# Altium schematic sheet Vapeix_mini_Shield.SchDoc
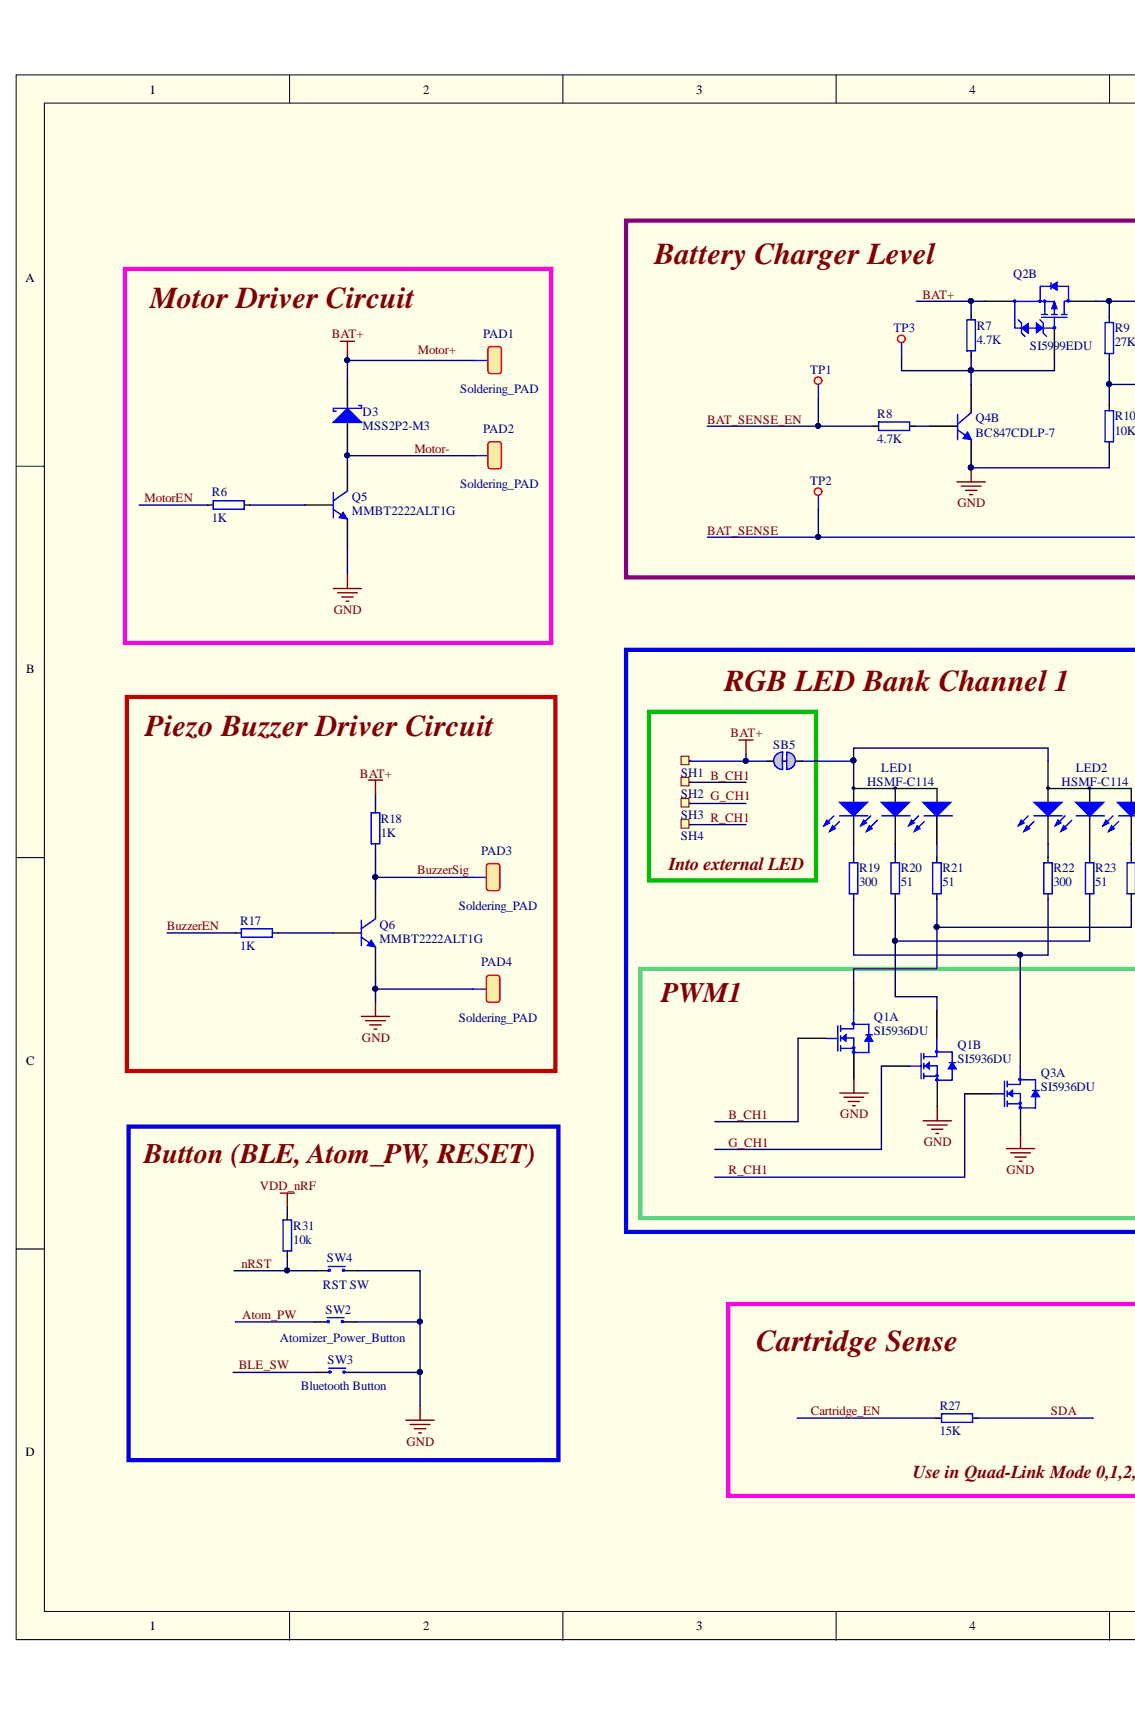
[diagram p0: Vapeix_mini_Shield.SchDoc, left]
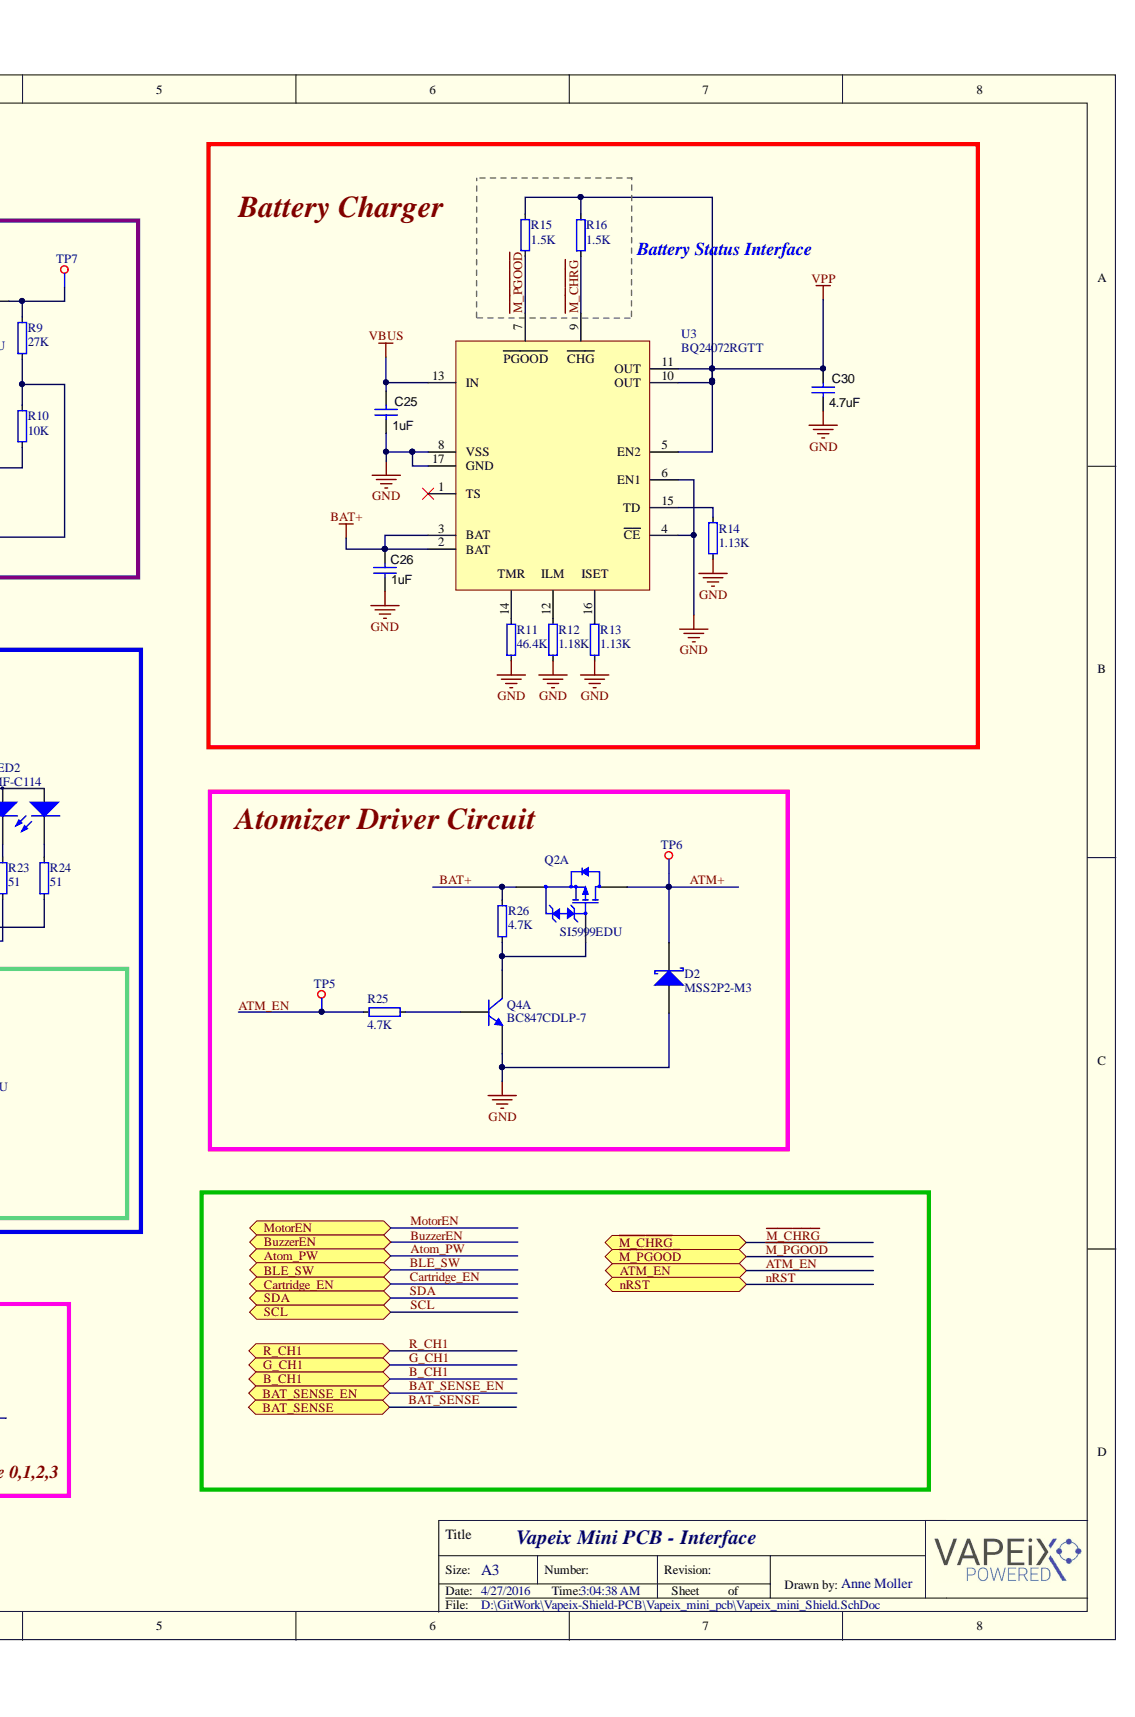
[diagram p1: Vapeix_mini_Shield.SchDoc, right]

## Parts
C25  ValueDisplayed: 1uF  [CAPC1608X06L]
C26  ValueDisplayed: 1uF  [CAPC1608X06L]
C30  ValueDisplayed: 4.7uF  [CAPC1608X06L]
D2  MSS2P2-M3  [MSS2P2-M3]
D3  MSS2P2-M3  [MSS2P2-M3]
LED1  HSMF-C114  [HSMF-C114]
LED2  HSMF-C114  [HSMF-C114]
PAD1  Soldering_PAD  [Soldering_PAD]
PAD2  Soldering_PAD  [Soldering_PAD]
PAD3  Soldering_PAD  [Soldering_PAD]
PAD4  Soldering_PAD  [Soldering_PAD]
Q1  SI5936DU  [SI5936DU]  (parts A,B)
Q2  SI5999EDU  [SI5999EDU]  (parts A,B)
Q3  SI5936DU  [SI5936DU]  (part A)
Q4  BC847CDLP-7  [BC847CDLP-7]  (parts A,B)
Q5  MMBT2222ALT1G  [MMBT2222ALT1G]
Q6  MMBT2222ALT1G  [MMBT2222ALT1G]
R6  1K  [RESC1005X04L]
R7  4.7K  [RESC1005X04L]
R8  4.7K  [RESC1005X04L]
R9  27K  [RESC1005X04L]
R10  10K  [RESC1005X04L]
R11  46.4K  [RESC1005X04L]
R12  1.18K  [RESC1005X04L]
R13  1.13K  [RESC1005X04L]
R14  1.13K  [RESC1005X04L]
R15  1.5K  [RESC1005X04L]
R16  1.5K  [RESC1005X04L]
R17  1K  [RESC1005X04L]
R18  1K  [RESC1005X04L]
R19  300  [RESC1005X04L]
R20  51  [RESC1005X04L]
R21  51  [RESC1005X04L]
R22  300  [RESC1005X04L]
R23  51  [RESC1005X04L]
R24  51  [RESC1005X04L]
R25  4.7K  [RESC1005X04L]
R26  4.7K  [RESC1005X04L]
R27  15K  [RESC1005X04L]
R31  10k  [RESC1005X04L]
SB5  Solderbridge  [Solderbridge_Shorted]  (value not drawn)
SH1  TP  [TESTPAD-TH]  (value not drawn)  (no BOM)
SH2  TP  [TESTPAD-TH]  (value not drawn)  (no BOM)
SH3  TP  [TESTPAD-TH]  (value not drawn)  (no BOM)
SH4  TP  [TESTPAD-TH]  (value not drawn)  (no BOM)
SW2  Atomizer_Power_Button  [ALPS_SKHUxxx010_WO_GND]
SW3  Bluetooth Button  [ALPS_SKHUxxx010_WO_GND]
SW4  RST SW  [ALPS_SKHUxxx010_WO_GND]
TP1  TP  [TP]
TP2  TP  [TP]
TP3  TP  [TP]
TP5  TP  [TP]
TP6  TP  [TP]
TP7  TP  [TP]
U3  BQ24072RGTT  [BQ24072RGTT]

## Ports
ATM_EN, ATOM_PW, B_CH1, BAT_SENSE, BAT_SENSE_EN, BLE_SW, BUZZEREN, CARTRIDGE_EN, G_CH1, MOTOREN, NRST, R_CH1, SCL, SDA, ~{M_CHRG}, ~{M_PGOOD}

## Nets
GND: C25.2 C26.2 C30.2 D2.1 PAD4.1 Q1.1 Q1.3 Q3.1 Q4.1 Q4.4 Q5.2 Q6.2 R10.2 R11.2 R12.2 R13.2 R14.2 U3.4(CE) U3.6(EN1) U3.8(VSS) U3.17(GND)
VBUS: C25.1 U3.13(IN)
VDD_NRF: R31.2
VPP: C30.1 R15.1 R16.1 U3.5(EN2) U3.10(OUT) U3.11(OUT)
ATM+: D2.2 Q2.7 TP6.1
ATM_EN: R25.1 TP5.1 ; port ATM_EN
ATOM_PW: SW2.1 ; port ATOM_PW
B_CH1: Q1.2 SH2.1 ; port B_CH1
BAT+: C26.1 D3.2 PAD1.1 Q2.1 Q2.3 R7.1 R18.1 R26.2 SB5.2 SH1.1 U3.2(BAT) U3.3(BAT)
BAT_SENSE: R9.2 R10.1 TP2.1 ; port BAT_SENSE
BAT_SENSE_EN: R8.2 TP1.1 ; port BAT_SENSE_EN
BLE_SW: SW3.1 ; port BLE_SW
BUZZEREN: R17.2 ; port BUZZEREN
BUZZERSIG: PAD3.1 Q6.3 R18.2
CARTRIDGE_EN: R27.2 ; port CARTRIDGE_EN
G_CH1: Q1.4 SH3.1 ; port G_CH1
~{M_CHRG}: R16.2 U3.9 ; port ~{M_CHRG}
~{M_PGOOD}: R15.2 U3.7 ; port ~{M_PGOOD}
MOTOR-: D3.1 PAD2.1 Q5.3
MOTOREN: R6.2 ; port MOTOREN
NRST: R31.1 SW4.1 ; port NRST
R_CH1: Q3.2 SH4.1 ; port R_CH1
SDA: R27.1 ; port SDA
NetLED1_1: LED1.1 LED2.1 SB5.1
NetLED1_2: LED1.2 R19.1
NetLED1_3: LED1.3 R20.1
NetLED1_4: LED1.4 R21.1
NetLED2_2: LED2.2 R22.1
NetLED2_3: LED2.3 R23.1
NetLED2_4: LED2.4 R24.1
NetQ1_5: Q1.5 R20.2 R23.2
NetQ1_7: Q1.7 R21.2 R24.2
NetQ2_2: Q2.2 Q4.3 R26.1
NetQ2_4: Q2.4 Q4.6 R7.2 TP3.1
NetQ2_5: Q2.5 R9.1 TP7.1
NetQ3_7: Q3.7 R19.2 R22.2
NetQ4_2: Q4.2 R25.2
NetQ4_5: Q4.5 R8.1
NetQ5_1: Q5.1 R6.1
NetQ6_1: Q6.1 R17.1
NetR11_1: R11.1 U3.14
NetR12_1: R12.1 U3.12
NetR13_1: R13.1 U3.16
NetR14_1: R14.1 U3.15(TD)
NetSW2_2: SW2.2 SW3.2 SW4.2
ATOMIZER DRIVER CIRCUIT:
BATTERY CHARGER:
BATTERY CHARGER LEVEL:
BATTERY STATUS INTERFACE:
BUTTON (BLE, ATOM_PW, RESET):
CARTRIDGE SENSE:
INTO EXTERNAL LED:
MOTOR DRIVER CIRCUIT:
MOTOR+:
PIEZO BUZZER DRIVER CIRCUIT:
PWM1:
RGB LED BANK CHANNEL 1:
SCL: ; port SCL
USE IN QUAD-LINK MODE 0,1,2,3:

Not connected: U3 1(TS)

## Library data of the parts
C25 C26 C30 = GRM219R61A106KE44D: CAP, CERM, 10 µF, 10 V, +/- 10%, X5R, 0805; Manufacturer: MuRata; Cap_Case: 0805; Cap_F: 0.00001; CompType: Capacitor; ESR_ohm: 0.005; Polarized: N; TaMax_C: 85; TaMin_C: -55; Tolerance_%: 10; U/M: EA; Value: 10uF; Value1: 0.005 ohm; Value2: 10V; Component Kind: Standard; ComponentLink1Description: Datasheet link; ComponentLink1URL: http://psearch.murata.com/capacitor/product/GRM219R61A106KE44%23.pdf; LatestRevisionDate: 2014-08-19; Library Name: Capacitor_Ceramic.IntLib; MountType: SMT; PackageReference: 0805; PartNumber: GRM219R61A106KE44D; Published: 2008-06-19; RoHS: Y; Signal Integrity: Cap; Simulation: Cap; Supplier 1: Digi-Key; Supplier 2: Mouser; Supplier 3: Farnell; Supplier Part Number 1: 490-4735-1-ND; Supplier Part Number 2: 81-GRM219R61A106KE4D; Supplier Part Number 3: 1845741; SymbolVersion: 2008-07-09; Technology: Ceramic; TempCo: X5R; VDC_V: 10; Publisher: Texas Instruments
D2 D3 = MSS2P2-M3/89A: DIODE SCHOTTKY 20V 2A MICROSMP; Manufacturer: Vishay Semiconductor Diodes Division; Capacitance @ Vr, F: 65pF @ 4V, 1MHz; Current - Reverse Leakage @ Vr: 250µA @ 20V; Speed: Fast Recovery =< 500ns, > 200mA (Io); Voltage - Forward (Vf) (Max) @ If: 600mV @ 2A; Category: Discrete Semiconductor Products; Component Kind: Standard; ComponentLink1Description: http://www.vishay.com/docs/89054/mss2p3.pdf; ComponentLink1URL: http://www.vishay.com/docs/89054/mss2p3.pdf; Current - Average Rectified (Io): 2A; Diode Type: Schottky; Manufacturer Part Number: MSS2P2-M3/89A; Mounting Type: Surface Mount; Operating Temperature - Junction: -55°C ~ 150°C; Package / Case: MicroSMP; Packaging: Digi-Reel®; Pricing 1: 1=0.37, 10=0.274, 100=0.1552, 500=0.1029, 1000=0.07892 (USD); Reverse Recovery Time (trr): -; RoHS: RoHS Compliant; Stock 1: 3961; Supplier 1: Digi-Key; Supplier Device Package: MicroSMP; Voltage - DC Reverse (Vr) (Max): 20V; Library Name: VapeixS_LIB.SchLib
LED1 LED2 = HSMF-C114: LED RGB DIFFUSED 0606 SMD; Manufacturer: Broadcom Limited; Color: Red, Green, Blue (RGB); Current - Test: 20mA Red, 20mA Green, 20mA Blue; Lens Color: White; Lens Style/Size: Rectangle with Flat Top, 1.10mm x 1.50mm; Millicandela Rating: 85mcd Red, 180mcd Green, 70mcd Blue; Viewing Angle: 140° Red, 145° Green, 145° Blue; Voltage - Forward (Vf) (Typ): 1.9V Red, 3.4V Green, 3.4V Blue; Wavelength - Dominant: 626nm Red, 525nm Green, 470nm Blue; Wavelength - Peak: 637nm Red, 523nm Green, 468nm Blue; Category: Optoelectronics; Component Kind: Standard; ComponentLink1Description: http://www.avagotech.com/docs/AV02-2451EN; ComponentLink1URL: http://www.avagotech.com/docs/AV02-2451EN; Features: -; Height (Max): 0.35mm; Lens Transparency: Diffused; Manufacturer Part Number: HSMF-C114; Mounting Type: Surface Mount; Package / Case: 0606 (1616 Metric); Packaging: Digi-Reel®; Pricing 1: 1=2.03, 10=1.62, 25=1.2376, 100=1.125, 250=0.99, 500=0.8325, 1000=0.72 (USD); RoHS: RoHS Compliant; Size / Dimension: 1.60mm L x 1.50mm W; Stock 1: 11787; Supplier 1: Digi-Key; Supplier Device Package: Chip LED; Library Name: VapeixS_LIB.SchLib
PAD1-PAD4 = Soldering_PAD: Soldering PAD; Component Kind: Standard; Library Name: VapeixS_LIB.SchLib
Q1 Q3 = SI5936DU-T1-GE3: MOSFET 2N-CH 30V 6A PWRPK CHPFET; Manufacturer: Vishay Siliconix; FET Feature: Logic Level Gate; FET Type: 2 N-Channel (Dual); Gate Charge (Qg) @ Vgs: 11nC @ 10V; Input Capacitance (Ciss) @ Vds: 320pF @ 15V; Power - Max: 10.4W; Rds On (Max) @ Id, Vgs: 30 mOhm @ 5A, 10V; Vgs(th) (Max) @ Id: 2.2V @ 250µA; Category: Discrete Semiconductor Products; Component Kind: Standard; ComponentLink1Description: http://www.vishay.com/docs/62804/si5936du.pdf; ComponentLink1URL: http://www.vishay.com/docs/62804/si5936du.pdf; Current - Continuous Drain (Id) @ 25°C: 6A; Drain to Source Voltage (Vdss): 30V; Manufacturer Part Number: SI5936DU-T1-GE3; Mounting Type: Surface Mount; Operating Temperature: -55°C ~ 150°C (TJ); Package / Case: PowerPAK® ChipFET™ Dual; Packaging: Cut Tape (CT); Pricing 1: 1=0.66, 10=0.578, 100=0.442, 500=0.3502, 1000=0.2805 (USD); RoHS: RoHS Compliant; Stock 1: 0; Supplier 1: Digi-Key; Supplier Device Package: PowerPAK® ChipFet Dual; Supplier Part Number 1: SI5936DU-T1-GE3CT-ND; Library Name: VapeixS_LIB.SchLib
Q2 = SI5999EDU-T1-GE3: MOSFET 2P-CH 20V 6A POWERPAK; Manufacturer: Vishay Siliconix; FET Feature: Logic Level Gate; FET Type: 2 P-Channel (Dual); Gate Charge (Qg) @ Vgs: 20nC @ 10V; Input Capacitance (Ciss) @ Vds: 496pF @ 10V; Power - Max: 10.4W; Rds On (Max) @ Id, Vgs: 59 mOhm @ 3.5A, 4.5V; Vgs(th) (Max) @ Id: 1.5V @ 250µA; Category: Discrete Semiconductor Products; Component Kind: Standard; ComponentLink1Description: http://www.vishay.com/docs/67019/si5999ed.pdf; ComponentLink1URL: http://www.vishay.com/docs/67019/si5999ed.pdf; Current - Continuous Drain (Id) @ 25°C: 6A; Drain to Source Voltage (Vdss): 20V; Manufacturer Part Number: SI5999EDU-T1-GE3; Mounting Type: Surface Mount; Operating Temperature: -55°C ~ 150°C (TJ); Package / Case: PowerPAK® ChipFET™ Dual; Packaging: Cut Tape (CT); Pricing 1: 1=0.58, 10=0.51, 100=0.39, 500=0.309, 1000=0.2475 (USD); RoHS: RoHS Compliant; Stock 1: 2994; Supplier 1: Digi-Key; Supplier Device Package: PowerPAK® ChipFet Dual; Library Name: VapeixS_LIB.SchLib
Q4 = BC847CDLP-7: TRANS 2NPN 45V 0.1A 6DFN; Manufacturer: Diodes Incorporated; Current - Collector (Ic) (Max): 100mA; Current - Collector Cutoff (Max): 15nA; DC Current Gain (hFE) (Min) @ Ic, Vce: 420 @ 2mA, 5V; Frequency - Transition: 100MHz; Power - Max: 350mW; Transistor Type: 2 NPN (Dual); Vce Saturation (Max) @ Ib, Ic: 600mV @ 5mA, 100mA; Category: Discrete Semiconductor Products; Component Kind: Standard; ComponentLink1Description: http://www.diodes.com/_files/datasheets/ds30817.pdf; ComponentLink1URL: http://www.diodes.com/_files/datasheets/ds30817.pdf; Manufacturer Part Number: BC847CDLP-7; Mounting Type: Surface Mount; Package / Case: 6-SMD, No Lead; Packaging: Cut Tape (CT); Pricing 1: 1=0.36, 10=0.29, 100=0.198, 500=0.1482, 1000=0.111 (USD); RoHS: RoHS Compliant; Stock 1: 11845; Supplier 1: Digi-Key; Supplier Device Package: 6-DFN1310H4 (1.0x1.3); Voltage - Collector Emitter Breakdown (Max): 45V; Library Name: VapeixS_LIB.SchLib
Q5 Q6 = MMBT2222ALT1G: TRANS NPN 40V 0.6A SOT23; Manufacturer: ON Semiconductor; Current - Collector (Ic) (Max): 600mA; Current - Collector Cutoff (Max): 10nA (ICBO); DC Current Gain (hFE) (Min) @ Ic, Vce: 100 @ 150mA, 10V; Frequency - Transition: 300MHz; Power - Max: 300mW; Vce Saturation (Max) @ Ib, Ic: 1V @ 50mA, 500mA; Category: Discrete Semiconductor Products; Component Kind: Standard; ComponentLink1Description: http://www.onsemi.com/pub_link/Collateral/MMBT2222LT1-D.PDF; ComponentLink1URL: http://www.onsemi.com/pub_link/Collateral/MMBT2222LT1-D.PDF; Manufacturer Part Number: MMBT2222ALT1G; Mounting Type: Surface Mount; Package / Case: TO-236-3, SC-59, SOT-23-3; Packaging: Cut Tape (CT); Pricing 1: 1=0.1, 10=0.095, 100=0.0516, 500=0.03172, 1000=0.02163 (USD); RoHS: RoHS Compliant; Stock 1: 0; Supplier 1: Digi-Key; Supplier Device Package: SOT-23; Transistor Type: NPN; Voltage - Collector Emitter Breakdown (Max): 40V; Library Name: VapeixS_LIB.SchLib
R6-R10 R17-R27 R31 = R0402-10k: Resistor, ±1%, 0.063W; Artikkelnr Noca: 27049097; Artikkelnr Simpro: N.A.; Database Table Name: Resistors$; Note: Chip Res 1%; Component Kind: Standard; Footprint Doc: 0402; LatestRevisionDate: 2009-11-23; Library Name: Nordic Passive DB.DbLib; Part Number: R0402-10k; Pin Count: 2; Published: 2009-05-08; Supplier: Farnell; Supplier part number: 173-8864; Publisher: Nordic Semiconductor
  R6 R17 R18: Value: 1K
  R7 R8 R25 R26: Value: 4.7K
  R9: Value: 27K
  R10: Value: 10K
  R19 R22: Value: 300
  R20 R21 R23 R24: Value: 51
  R27: Value: 15K
  R31: Value: 10k
R11-R16 = R0402-10k: Resistor, ±1%, 0.063W; Artikkelnr Noca: 27049097; Artikkelnr Simpro: N.A.; Database Table Name: Resistors$; Note: Chip Res 1%; Value: 10K; Component Kind: Standard; Footprint Doc: 0402; LatestRevisionDate: 2009-11-23; Library Name: Nordic Passive DB.DbLib; Part Number: R0402-10k; Pin Count: 2; Published: 2009-05-08; Supplier: Farnell; Supplier part number: 173-8864; Publisher: Nordic Semiconductor
SB5 = Solderbridge Shorted: Shorted Solderbridge; Artikkelnr Noca: N.A.; Database Table Name: Connectors$; Artikkelnr Simpro: N.A.; Component Kind: Net Tie; Footprint Doc: Solderbridge_Shorted; LatestRevisionDate: 2012-08-07; Library Name: Nordic Passive DB.DbLib; Part Number: Solderbridge Shorted; Pin Count: 2; Published: 2012-08-07; Value: Solderbridge; Publisher: Nordic Semiconductor
SH1-SH4 = (no symbol name): Testpoint, through hole; Part Number: Testpoint TH; Artikkelnr Noca: N.A.; Artikkelnr Simpro: N.A.; Footprint Doc: TESTPAD-TH; LatestRevisionDate: 2009-12-12; Library Name: pca10040.SCHLIB; Pin Count: 1; Published: 2009-12-12; Value: TP; Publisher: Nordic Semiconductor
SW2 SW3 = ALPS_SKHUALE010: ALPS - SKHUALE010 - Tactile Switch, SPNO, SMD, 6.5x6.2x2.5mm; 2nd source part number: 688-SKHUALE010; Artikkelnr Noca: 50019001; Artikkelnr Simpro: N.A.; Database Table Name: Rele_Switch$; Value: RST SW; 2nd source Supplier: Mouser; Component Kind: Standard; Datasheet: https://www1.elfa.se/data1/wwwroot/webroot/Z_DATA/03579000.pdf; Footprint Doc: ALPS_SKHUxxx010_WO_GND; LatestRevisionDate: 2010-02-01; Library Name: Nordic Discrete DB.DbLib; Manufacturer: Alps; Manufacturer Part Number: SKHUALE010; Part Number: ALPS_SKHUALE010; Pin Count: 4; Published: 2010-02-01; Supplier: Elfa; Supplier part number: 35-790-00; Publisher: Nordic Semiconductor
SW4 = ALPS_SKHUALE010: ALPS - SKHUALE010 - Tactile Switch, SPNO, SMD, 6.5x6.2x2.5mm; 2nd source part number: 688-SKHUALE010; Artikkelnr Noca: 50019001; Artikkelnr Simpro: N.A.; Database Table Name: Rele_Switch$; 2nd source Supplier: Mouser; Component Kind: Standard; Datasheet: https://www1.elfa.se/data1/wwwroot/webroot/Z_DATA/03579000.pdf; Footprint Doc: ALPS_SKHUxxx010_WO_GND; LatestRevisionDate: 2010-02-01; Library Name: Nordic Discrete DB.DbLib; Manufacturer: Alps; Manufacturer Part Number: SKHUALE010; Part Number: ALPS_SKHUALE010; Pin Count: 4; Published: 2010-02-01; Supplier: Elfa; Supplier part number: 35-790-00; Value: RST SW; Publisher: Nordic Semiconductor
TP1-TP3 TP5-TP7 = TP: TEST POINT; Component Kind: Standard; Library Name: VapeixS_LIB.SchLib
U3 = BQ24072RGTT: TEXAS INSTRUMENTS - BQ24072RGTT - BATTERY CHARGER LI-ION, 1.5A, QFN-16; Battery Charge Voltage: 4.2; Battery IC Case Style: QFN; Charge Current Max: 1.2; Input Voltage: 26; No. of Pins: 16; No. of Series Cells: 1; Battery Type: Li-Ion; Component Kind: Standard; ComponentLink1Description: http://www.farnell.com/cad/1638885.zip; ComponentLink1URL: http://www.farnell.com/cad/1638885.zip; ComponentLink2Description: http://www.farnell.com/datasheets/2001589.pdf; ComponentLink2URL: http://www.farnell.com/datasheets/2001589.pdf; Manufacturer: TEXAS INSTRUMENTS; Manufacturer Part Number: BQ24072RGTT; Operating Temperature Min: -40 C; Pricing 1: 1=1.6, 10=1.6, 25=1.47, 50=1.47, 100=1.47 (USD); RoHS: YES; Stock 1: 134; Supplier 1: Newark; Supplier Part Number 1: 12P6258; Library Name: VapeixS_LIB.SchLib
